# Altium schematic sheet Nixie_Boost.SchDoc
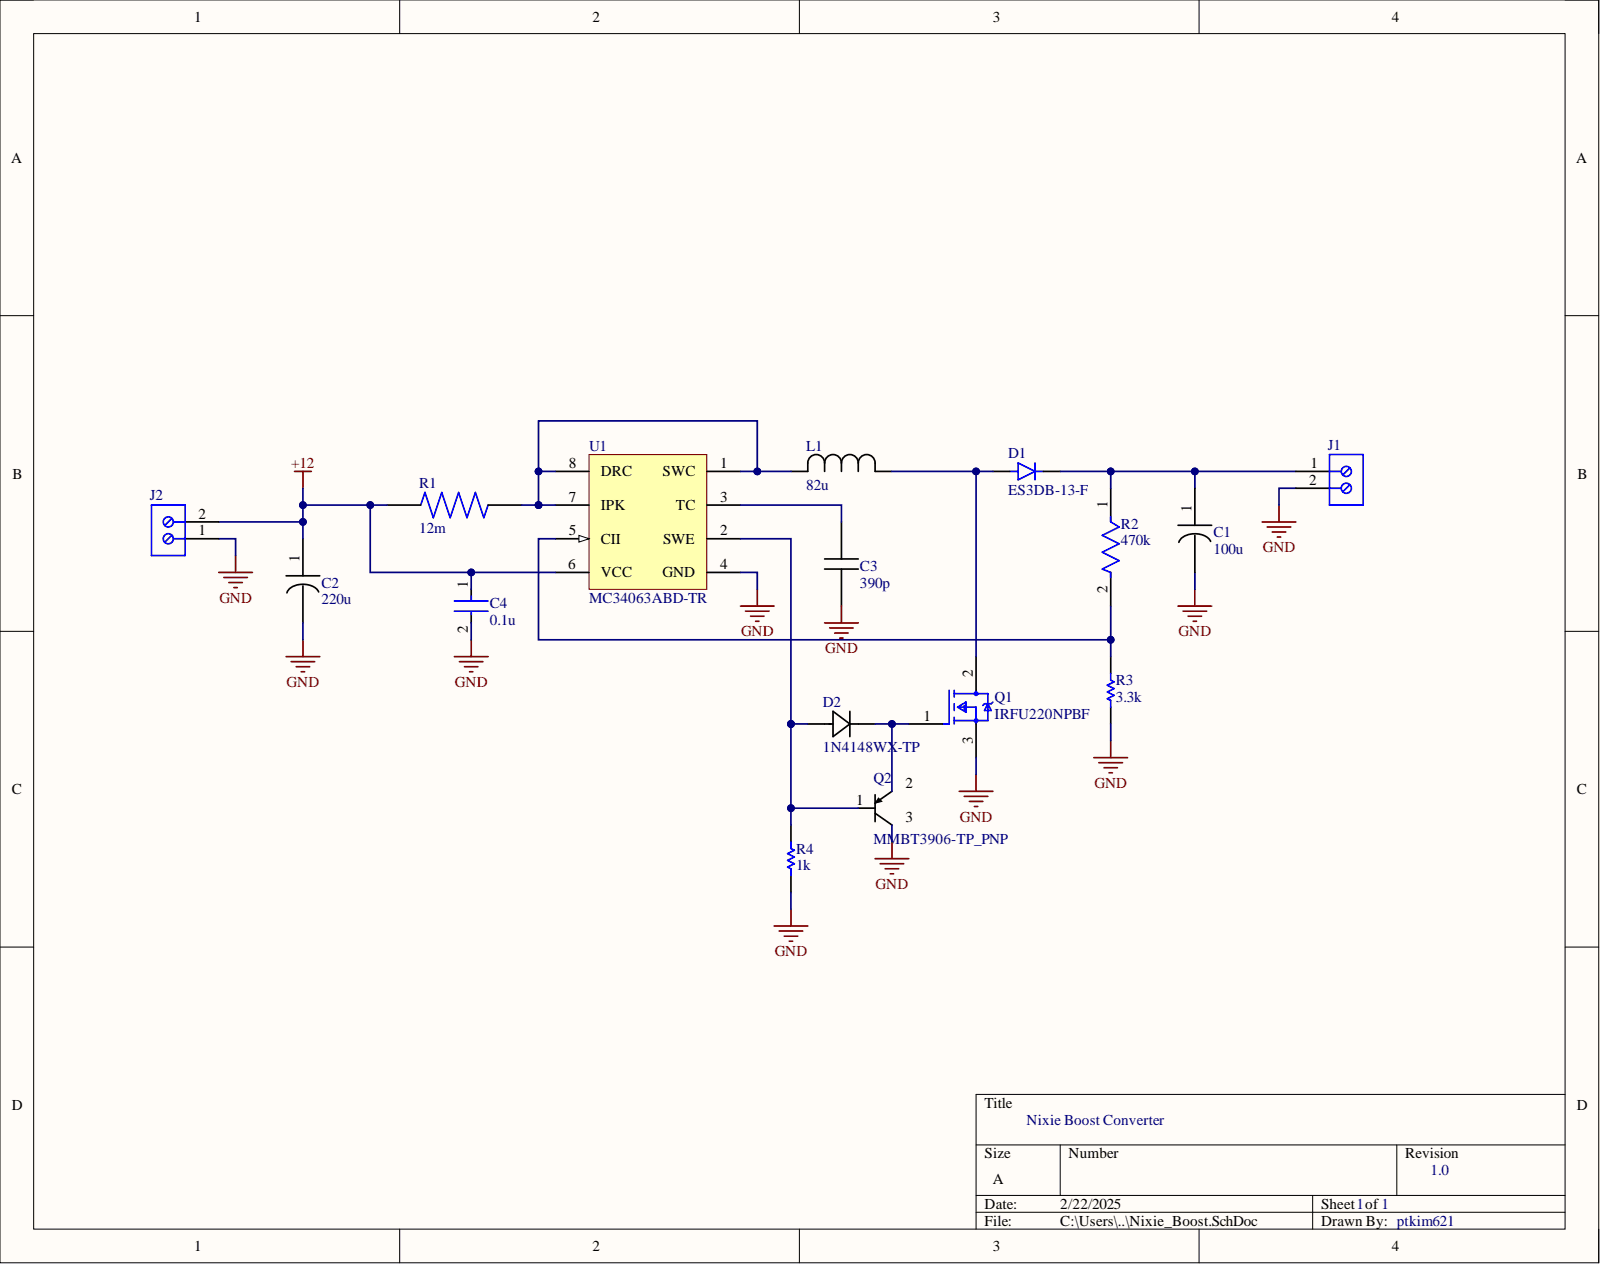
[diagram p0: Nixie_Boost.SchDoc]

## Parts
C1  100u  [ESH107M200AM7AA_100uF]
C2  220u  [EL1E221MP26311U_220uF]
C3  390p  [CC0603JRNPO9BN391]
C4  0.1u  [FP-C0603C-CF-IPC_B]
D1  ES3DB-13-F  [DIOM4336X265N]
D2  1N4148WX-TP  [CR_SOD323_MCC]
J1  CMP-1502-03324-2  [691137710002]  (value not drawn)
J2  CMP-1502-03324-2  [691137710002]  (value not drawn)
L1  82u  [IND_BOURNS_SRR1210]
Q1  IRFU220NPBF  [FP-PG-TO251-3-MFG]
Q2  MMBT3906-TP_PNP  [MMBT3906-TP_PNP]
R1  12m  [RESC6331X89N]
R2  470k  [FP-RT0805-MFG]
R3  3.3k  [RESC2013X60X35NL10T20]
R4  1k  [RESC3116X65X40ML10T20]
U1  MC34063ABD-TR  [SO8_N]

## Nets
+12: C2.1 C4.1 J2.2 R1.1 U1.6(VCC)
GND: C1.2 C2.2 C3.2 C4.2 J1.2 J2.1 Q1.3 Q2.3 R3.1 R4.1 U1.4(GND)
NetC1_1: C1.1 D1.C J1.1 R2.1
NetC3_1: C3.1 U1.3(TC)
NetD1_A: D1.A L1.1 Q1.2
NetD2_1: D2.1 Q1.1 Q2.2
NetD2_2: D2.2 Q2.1 R4.2 U1.2(SWE)
NetL1_2: L1.2 R1.2 U1.1(SWC) U1.7(IPK) U1.8(DRC)
NetR2_2: R2.2 R3.2 U1.5(CII)

## Library data of the parts
C1 = ESH107M200AM7AA_100uF: 100 µF 200 V Aluminum Electrolytic Capacitors Radial, Can 2000 Hrs @ 105°C; Component Kind: Standard; Library Name: Nixie_BoostConverter_Library.IntLib
C2 = EL1E221MP26311U: 220 µF 25 V Aluminum Electrolytic Capacitors Radial, Can 2000 Hrs @ 105°C; Component Kind: Standard; Library Name: Nixie_BoostConverter_Library.IntLib
C3 = CC0603JRNPO9BN391: 390 pF ±5% 50V Ceramic Capacitor C0G, NP0 0603 (1608 Metric); Component Kind: Standard; Library Name: Nixie_BoostConverter_Library.IntLib
C4 = CMP-2006-03068-2: CAP CER 0.1UF 50V 20% X7R 0603; Manufacturer: KEMET; Manufacturer Part Number: C0603C104M5RACTU; Automotive Grade: Grade 1; Capacitance: 0.10 μF; Material: Ceramic; Temperature Coefficient: 30ppm/°C; Tolerance: 0.2; Value: 0.10 μF; Automotive: Yes; Category: Cap; Component Kind: Standard; ComponentLink2Description: Datasheet; ComponentLink2URL: https://api.kemet.com/component-edge/download/datasheet/C0603C100J5GACAUTO.pdf; Device Class L1: Passive Components; Device Class L2: Capacitors; Device Class L3: Ceramic Capacitors; Digikey Description: CAP CER 0.1UF 50V 20% X7R 0603; Height: 0.87mm; Lead Free: Yes; Library Name: Nixie_BoostConverter_Library.IntLib; Mouser Description: Multilayer Ceramic Capacitors MLCC - SMD/SMT 50volts 0.1uF X7R 20%; Octopart: https://octopart.com/c0603c104m5ractu-kemet-11855687; Package: C0603C-CF; RoHS: TRUE; Temperature: -55°C to +125°C; Temperature Characteristic: X7R; Temperature Range High: +125°C; Temperature Range Low: -55°C; Voltage Rating: 50 V
D1 = ES3DB-13-F: Diode 200 V 3A Surface Mount SMB; MF: {'manufacturer': u'Diodes Incorporated'}; Availability: Unavailable; Component Kind: Standard; Library Name: Nixie_BoostConverter_Library.IntLib; MP: ES3DB-13-F; Package: None; Price: None
D2 = 1N4148WX-TP: Diode 75 V 150mA Surface Mount SOD-323; Mfr_Name: MCC; Component Kind: Standard; Library Name: Nixie_BoostConverter_Library.IntLib
J1 J2 = CMP-1502-03324-2: 2 Position Wire to Board Terminal Block Horizontal with Board 0.197" (5.00mm) Through Hole; NexarPartId: 78871135; Current Rating: 16A; Number of Contacts: 2; Voltage Rating: 300V; Component Kind: Standard; Contact Material: Nickel, Tin; Height: 11.6mm; Lead Pitch: 5mm; Library Name: Nixie_BoostConverter_Library.IntLib; Max Operating Temperature: 120°C; Min Operating Temperature: -30°C; Number of Pins: 2; Number of Rows: 1; Packaging: Box; RoHS: Non-Compliant
L1 = SRR1210-820M: 82 µH Shielded Drum Core, Wirewound Inductor 2.8 A 112mOhm Max Nonstandard; Mfr_Name: Bourns Electronics; Component Kind: Standard; Library Name: Nixie_BoostConverter_Library.IntLib
Q1 = IRFU220NPBF: MOSFET N-CH 200V 5A IPAK; Drain to Source Resistance: 600mR; Gate to Source Voltage (Vgs): 20V; Input Capacitance: 300pF; Max Power Dissipation: 43W; Threshold Voltage: 4V; Turn-Off Delay Time: 20ns; Turn-On Delay Time: 6.4ns; Case/Package: TO-251-3; Component Kind: Standard; Continuous Drain Current (ID): 5A; Drain to Source Breakdown Voltage: 200V; Drain to Source Voltage (Vdss): 200V; Halogen Free: Not Halogen Free; Height: 0.245inch; Lead Free: Contains Lead; Length: 0.264inch; Library Name: Nixie_BoostConverter_Library.IntLib; Max Dual Supply Voltage: 200V; Max Operating Temperature: 175°C; Min Operating Temperature: -55°C; Mount: Through Hole; Number of Pins: 3; Packaging: Rail/Tube; Power Dissipation: 43W; Rds On Max: 600mR; REACH SVHC: No SVHC; RoHS Compliant: Yes; Width: 0.094inch
Q2 = MMBT3906-TP_PNP: Bipolar (BJT) Transistor PNP 40 V 200 mA 250MHz 300 mW Surface Mount SOT-23; Component Kind: Standard; Library Name: Nixie_BoostConverter_Library.IntLib
R1 = PE2512FKE7W0R012L: 12 mOhms ±1% 2W Chip Resistor 2512 (6432 Metric) Automotive AEC-Q200, Current Sense Metal Film; Availability: Unavailable; Component Kind: Standard; Library Name: Nixie_BoostConverter_Library.IntLib; MP: PE2512FKE7W0R012L; Package: None; Price: None
R2 = CMP-03412-002752-1: RES SMD 470K OHM 0.5% 1/8W 0805; Manufacturer: Yageo; Manufacturer Part Number: RT0805DRE07470KL; Power Rating: 0.125W; Resistance: 470KΩ; Temperature Coefficient: 50 ppm/°C; Tolerance: 0.01; Value: 470KΩ; Voltage Rating: 150V; Automotive: No; Category: Res; Component Kind: Standard; ComponentLink2Description: Datasheet; ComponentLink2URL: http://www.yageo.com/documents/recent/PYu-RT_1-to-0.01_RoHS_L_9.pdf; Composition: Thin Film; Device Class L1: Passive Components; Device Class L2: Resistors; Device Class L3: Chip SMD Resistors; Digikey Description: RES SMD 470K OHM 0.5% 1/8W 0805; Footprint Url: http://www.yageo.com/exep/pages/download/literatures/PYu-R_Mount_10.pdf; Height: 0.6mm; Ipc Land Pattern Name: RESC200125X50; Lead Free: Yes; Library Name: Nixie_BoostConverter_Library.IntLib; Octopart: https://octopart.com/rt0805dre07470kl-yageo-1232546; Package: RT0805; RoHS: TRUE; Series: RT; Temperature: -55°C to +155°C; Temperature Range High: +155°C; Temperature Range Low: -55°C
R3 = CMP-1013-00567-2: Chip Resistor, 3.3 KOhm, +/- 1%, 0.125 W, -55 to 155 degC, 0805 (2012 Metric), RoHS, Tape and Reel; Manufacturer: Yageo; Max Power Dissipation: 125mW; Temperature Coefficient: 100ppm/degreesC; Voltage Rating: 150V; Case Code (Imperial): 0805; Case Code (Metric): 2012; Case/Package: 0805; Component Kind: Standard; Composition: ThickFilm; Datasheet URL: http://www.yageo.com/NewPortal/yageodocoutput?fileName=/pdf/R-Chip/PYu-RC_Group_51_RoHS_L_5.pdf; Datasheet Version: Rev. 5, 10/2016; Depth: 1.25mm; Height: 0.5mm; Lead Free: LeadFree; Length: 2mm; Library Name: Nixie_BoostConverter_Library.IntLib; Manufacturer URL: http://www.yageo.com/; Max Operating Temperature: 155degC; Min Operating Temperature: -55degC; Number of Terminations: 2; Package Description: 2-Pin Surface Mounted Device, Body 2 x 1.25 mm; Package Quantity: 1; Package Reference: 0805; Packaging: TapeandReel; Power Rating: 125mW; REACH SVHC: NoSVHC; Resistance: 3.3KOhm; RoHSCompliant: true; Termination: SMD/SMT; Tolerance: 1%; Weight: 0.000194oz; Width: 0.049inch
R4 = CMP-2100-03678-1: Chip Resistor, 1 KOhm, +/- 1%, 0.25 W, -55 to 155 degC, 1206 (3216 Metric), RoHS, Tape and Reel; Manufacturer: Yageo; Power Rating: 250mW; Resistance: 1KOhm; Temperature Coefficient: 100ppm/degreesC; Voltage Rating: 200V; Case Code (Imperial): 1206; Case Code (Metric): 3216; Case/Package: 1206; Component Kind: Standard; Composition: ThickFilm; Datasheet URL: http://www.yageo.com/NewPortal/yageodocoutput?fileName=/pdf/R-Chip/PYu-RC_Group_51_RoHS_L_5.pdf; Datasheet Version: Rev. 5, 10/2016; Depth: 1.6mm; Height: 0.55mm; Lead Free: LeadFree; Length: 3.1mm; Library Name: Nixie_BoostConverter_Library.IntLib; Manufacturer URL: http://www.yageo.com/; Max Operating Temperature: 155degC; Military Standard: Not; Min Operating Temperature: -55degC; Package Description: 2-Pin Surface Mount Device, Body 3.1 x 1.6 mm; Package Reference: 1206.0; Packaging: TapeandReel; REACH SVHC: Unknown; RoHSCompliant: true; Termination: SMD/SMT; Tolerance: 1%
U1 = CMP-0241-00017-1: DC/DC converter control circuit, 8-Pin SO, Tape and Reel; Code_IPC: SOIC127P600-8; Code_JEDEC: MS-012-AA; Component Kind: Standard; ComponentLink1Description: Manufacturer URL; ComponentLink1URL: http://www.st.com; ComponentLink2Description: Datasheet; ComponentLink2URL: http://www.st.com/internet/com/TECHNICAL_RESOURCES/TECHNICAL_LITERATURE/DATASHEET/CD00001232.pdf; ComponentLink3Description: Package Specification; ComponentLink3URL: http://www.st.com/internet/com/TECHNICAL_RESOURCES/TECHNICAL_LITERATURE/PACKAGE_INFORMATION/CD00004680.pdf; DatasheetVersion: Nov-2007; Library Name: Nixie_BoostConverter_Library.IntLib; PackageDescription: 8-Pin Small Outline Integrated Circuit 1.27 mm Pitch; PackageReference: SO8; PackageVersion: Dec-2002
